# Altium schematic sheet DRV11873.SchDoc
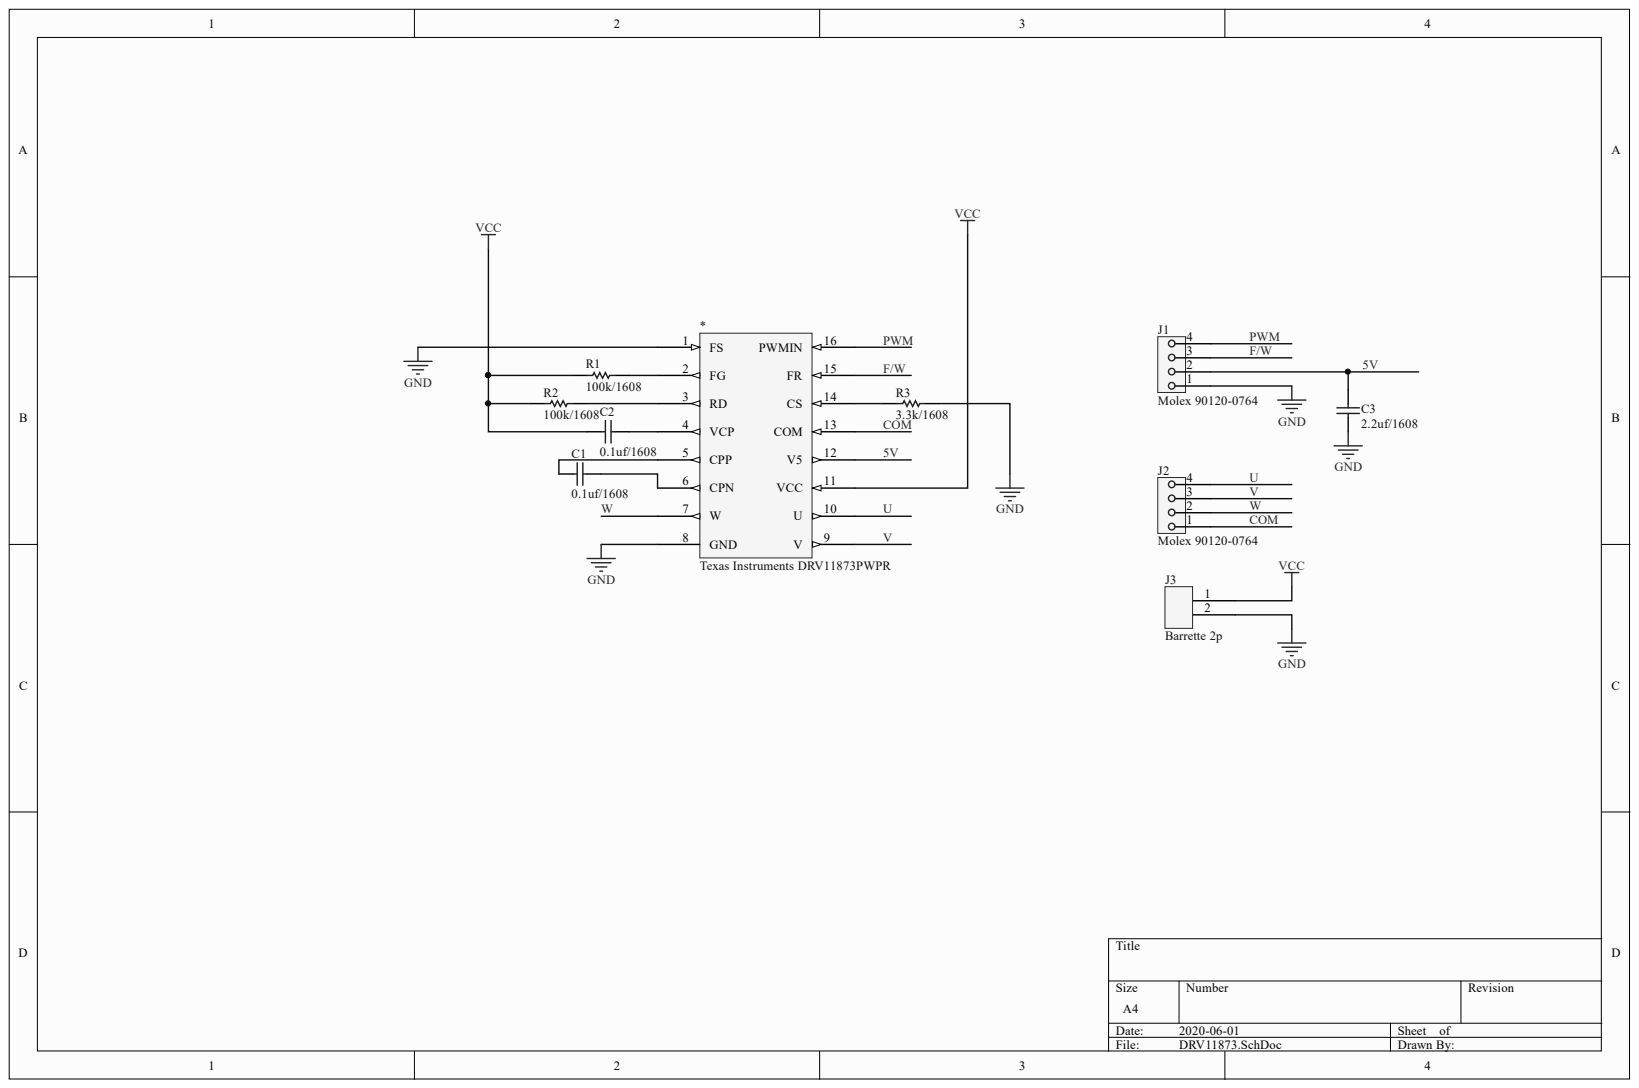
[diagram p0: DRV11873.SchDoc]

## Parts
*  Texas Instruments DRV11873PWPR  Manufacturer Part Number: DRV11873PWPR  [PCB-gl35ubmjhk6nz3i99m29-1]
C1  0.1uf/1608  [CAPC1608X90X35LL15T15]
C2  0.1uf/1608  [CAPC1608X90X35LL15T15]
C3  2.2uf/1608  [PCB-ut6qvzar43b06cy077h6-1]
J1  Molex 90120-0764  Manufacturer Part Number: 90120-0764  [PCB-mruwntkvy73mrw75dg9m-1]
J2  Molex 90120-0764  Manufacturer Part Number: 90120-0764  [PCB-mruwntkvy73mrw75dg9m-1]
J3  Barrette 2p  [PCB-ayp4uy6mce3kuznu7cpv-1]
R1  100k/1608  [PCB-3r7gkicy0hnjsi8s7sje-1]
R2  100k/1608  [PCB-3r7gkicy0hnjsi8s7sje-1]
R3  3.3k/1608  [RESC1608X55X30LL15T15]

## Nets
GND: *.1(FS) *.8(GND) C3.1 J1.1 J3.2 R3.2
VCC: *.11(VCC) C2.1 J3.1 R1.1 R2.1
5V: *.12(V5) C3.2 J1.2
COM: *.13(COM) J2.1
F/W: *.15(FR) J1.3
PWM: *.16(PWMIN) J1.4
U: *.10(U) J2.4
V: *.9(V) J2.3
W: *.7(W) J2.2
Net*_2: *.2(FG) R1.2
Net*_3: *.3(RD) R2.2
Net*_4: *.4(VCP) C2.2
Net*_5: *.5(CPP) C1.1
Net*_6: *.6(CPN) C1.2
Net*_14: *.14(CS) R3.1

## Library data of the parts
* = CMP-c0ea0868271e1f2d-1: 12-V, 3-Phase Sensorless BLDC Motor Controller 16-HTSSOP -40 to 85; Lead-Free Status: Lead Free; Number of Outputs: 2; Output Current: 1.50 A; Supply Voltage (DC): 5.00 V (min); Component Kind: Standard; Library Name: CommunityVault; Lifecycle Status: Active; Number of Pins: 16; OctopartID: c0ea0868271e1f2d; Octopart URL: http://octopart.com/drv11873pwpr-texas+instruments-25739922; REACH SVHC Compliance: No SVHC; RoHS: Compliant
C1 C2 = CMP-3016f860c6a7efd1-1: CL10 Series 0.1 uF 100 V ±10 % Tolerance X7R SMT Multilayer Ceramic Capacitor; Capacitance: 100 nF; Lead-Free Status: Lead Free; Case/Package: 0603; Component Kind: Standard; Dielectric Characteristic: X7R; Library Name: CommunityVault; OctopartID: 3016f860c6a7efd1; Octopart URL: http://octopart.com/cl10b104kc8nnnc-samsung-24957911
C3 = CMP-3568fc1ddfcfeaaf-3: Capacitor: ceramic; MLCC; 2.2uF; 10V; X5R; ±10%; SMD; 0603; -55÷85°C; Capacitance: 2.20 µF; Component Kind: Standard; Library Name: CommunityVault
J1 J2 = CMP-f5c93cc9d0ed2a0e-7: 2.54mm (.100") Pitch C-Grid III Header, Single Row, Vertical, 3 Circuits, 0.38µm (15µ") Gold (Au) Selective Plating; Contact Resistance: 20.0 mΩ; Current Rating: 3.00 A; Gender: Male; Glow Wire Compliant: Non-Compliant; Insulation Resistance: 5.00 GΩ; Lead-Free Status: Lead Free; Number of Circuits: 3; Number of Contacts: 4; Voltage Rating (AC): 350 V; Component Kind: Standard; Contact Material: Copper; Contact Plating: Gold; Halogen Free Status: Not Halogen Free; Housing Color: Black; Housing Material: Plastic; Library Name: CommunityVault; Lifecycle Status: Not Listed by Manufacturer; Material: Polyester; Mounting Style: Through Hole, Vertical; Number of Positions: 4; Number of Rows: 1; OctopartID: f5c93cc9d0ed2a0e; Octopart URL: http://octopart.com/90120-0764-molex-655426; Orientation: Vertical; Packaging: Bag; Pin Pitch: 2.54 mm; RoHS: Compliant; Size-Height: 2.50 mm; Size-Length: 10.2 mm; Size-Width: 2.54 mm; Voltage Rating (DC): 350 V
J3 = CMP-db3d12a8237904fa-7: Barrette de 2 contacts; Number of Contacts: 2; Component Kind: Standard; Library Name: CommunityVault; OctopartID: db3d12a8237904fa; Octopart URL: http://octopart.com/0022032021-molex-10476834
R1 R2 = CMP-95fe5cf5be9e4a39-1: RC Series 1608 100 kOhms ±5% 0.1 W ±100 ppm/°C SMT Thick Film Chip Resistor; Manufacturer Part Number: RC1608J104CS; Case/Package (SI): 1608; Lead-Free Status: Lead Free; Power Rating: 100 mW; Resistance: 100 kΩ; Voltage Rating (DC): 50.0 V; Case/Package: 0603; Component Kind: Standard; Library Name: CommunityVault; Mounting Style: Surface Mount; OctopartID: 95fe5cf5be9e4a39; Octopart URL: http://octopart.com/rc1608j104cs-samsung-69126; RoHS: Compliant; Size-Height: 450 µm; Size-Length: 1.60 mm; Size-Width: 800 µm
R3 = CMP-3656259-2: Thick Film Resistors - SMD 0603 3.3Kohms 5% Tol; Manufacturer Part Number: ERJ-3GEYJ332V; Lead-Free Status: Lead Free; Power Rating: 0.1 W; Resistance: 3300.0 Ω; Voltage Rating (DC): 75.0 V; Case/Package: 0603; Component Kind: Standard; Library Name: CommunityVault; Lifecycle Status: Not Listed by Manufacturer; Mounting Style: Surface Mount; OctopartID: f2c038877b272d07; Octopart Url: https://octopart.com/erj-3geyj332v-panasonic-55422081; Packaging: Cut Tape (CT); REACH SVHC Compliance: No SVHC; Resistance Tolerance: ±5%; RoHS: Compliant; Size-Height: 0.00045 m; Size-Length: 0.0016 m; Size-Width: 0.0008 m
